# Altium schematic sheet Battery Management System.SchDoc
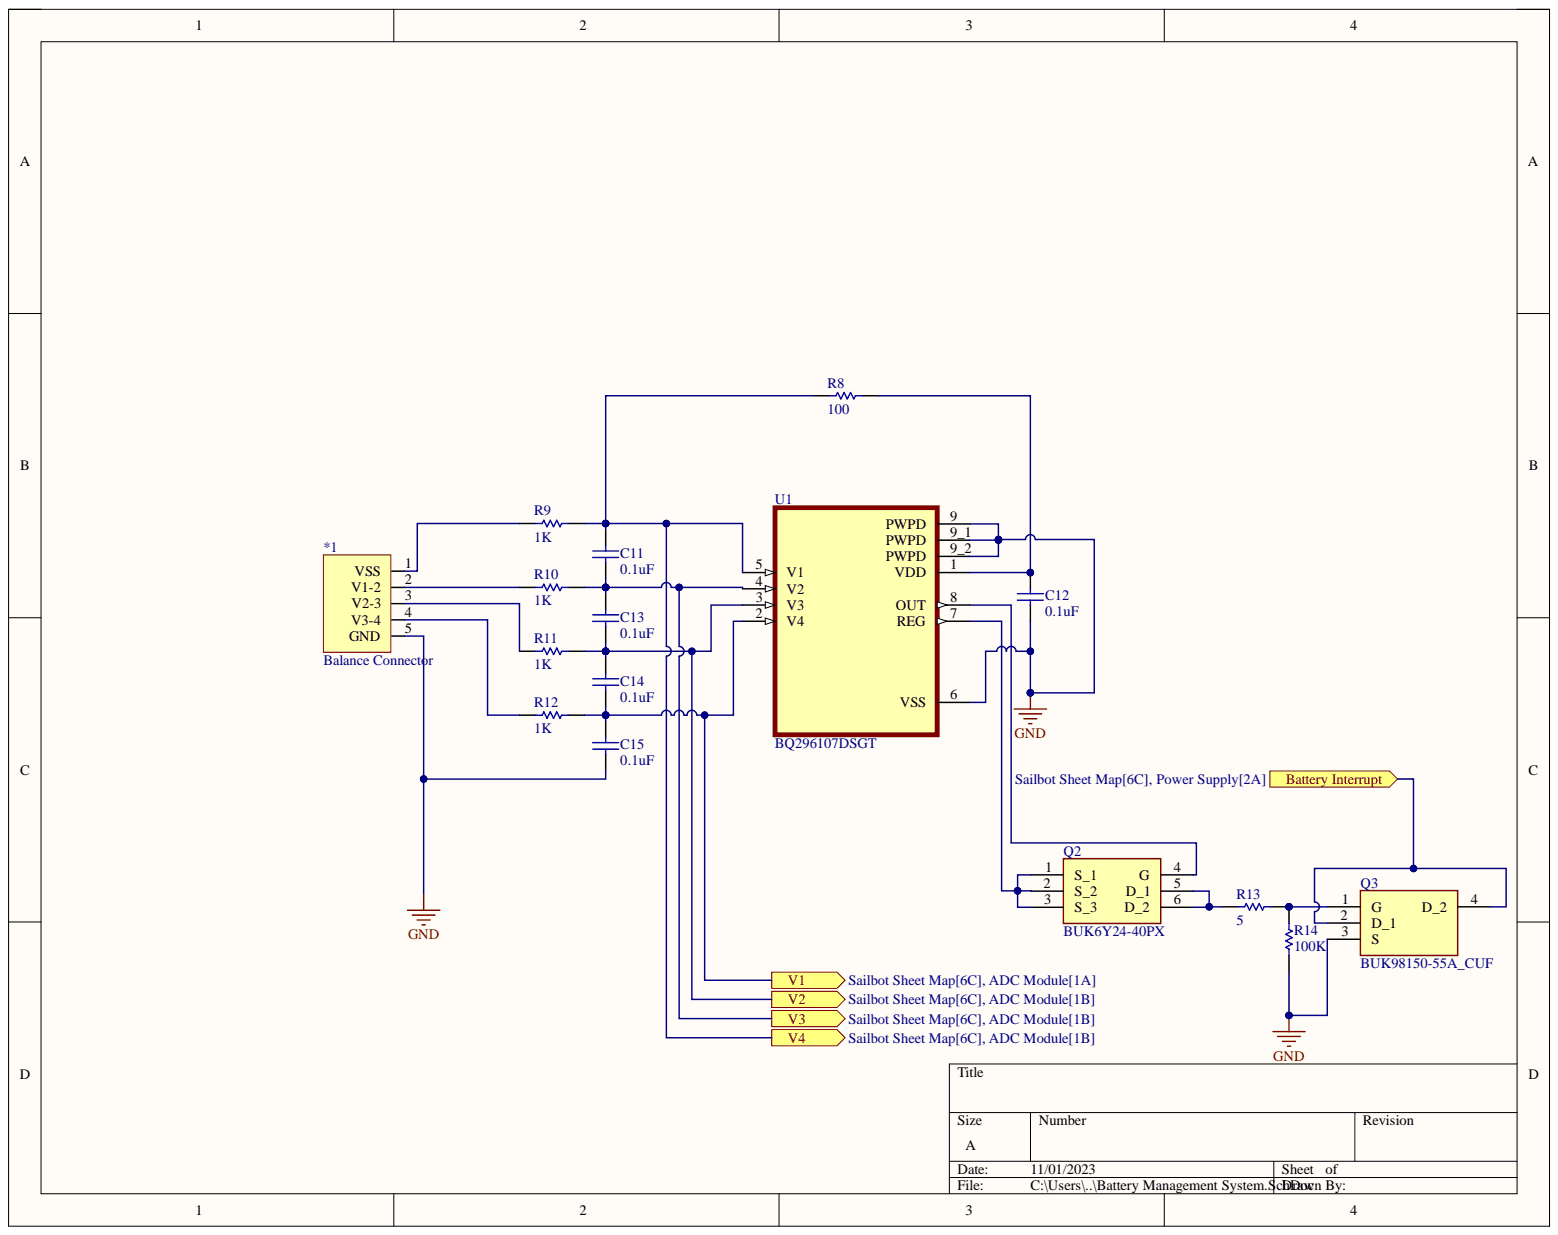
[diagram p0: Battery Management System.SchDoc]

## Parts
*1  Balance Connector
C11  value 0.1uF  (hidden comment: Capacitor)
C12  value 0.1uF  (hidden comment: Capacitor)
C13  value 0.1uF  (hidden comment: Capacitor)
C14  value 0.1uF  (hidden comment: Capacitor)
C15  value 0.1uF  (hidden comment: Capacitor)
Q2  BUK6Y24-40PX  [BUK6Y2440PX]
Q3  BUK98150-55A_CUF  [SOT230P700X180-4N]
R8  value 100  (hidden comment: Resistor)
R9  value 1K  (hidden comment: Resistor)
R10  value 1K  (hidden comment: Resistor)
R11  value 1K  (hidden comment: Resistor)
R12  value 1K  (hidden comment: Resistor)
R13  value 5  (hidden comment: Resistor)
R14  value 100K  (hidden comment: Resistor)
U1  BQ296107DSGT  [SON50P200X200X80-9N]

## Ports
Battery Interrupt, V1, V2, V3, V4

## Nets
GND: *1.5(GND) C12.1 C15.1 Q3.3(S) R14.1 U1.6(VSS) U1.9(PWPD) U1.9_1(PWPD) U1.9_2(PWPD)
Battery Interrupt: Q3.2(D_1) Q3.4(D_2) ; port Battery Interrupt
V1: C14.1 C15.2 R12.2 U1.2(V4) ; port V1
V2: C13.1 C14.2 R11.2 U1.3(V3) ; port V2
V3: C11.1 C13.2 R10.2 U1.4(V2) ; port V3
V4: C11.2 R8.1 R9.2 U1.5(V1) ; port V4
Net*1_1: *1.1(VSS) R9.1
Net*1_2: *1.2(V1-2) R10.1
Net*1_3: *1.3(V2-3) R11.1
Net*1_4: *1.4(V3-4) R12.1
NetC12_2: C12.2 R8.2 U1.1(VDD)
NetQ2_1: Q2.1(S_1) Q2.2(S_2) Q2.3(S_3) U1.7(REG)
NetQ2_4: Q2.4(G) U1.8(OUT)
NetQ2_5: Q2.5(D_1) Q2.6(D_2) R13.1
NetQ3_1: Q3.1(G) R13.2 R14.2

## Library data of the parts
C11-C15 = Capacitor: SimulationGenericComponent: True; Component Kind: Standard; Description: Capacitor; Library Name: Simulation Generic Components.IntLib; Simulation: C_Capacitor_Default
Q2 = BUK6Y24-40PX: NEXPERIA - BUK6Y24-40PX - Power MOSFET, P Channel, 40 V, 39 A, 0.019 ohm, LFPAK56, Surface Mount; Geometry.Height: 1.2mm; Component Kind: Standard; Datasheet Link: https://assets.nexperia.com/documents/data-sheet/BUK6Y24-40P.pdf; Manufacturer_Name: Nexperia; Manufacturer_Part_Number: BUK6Y24-40PX; Mouser Part Number: 771-BUK6Y24-40PX; Mouser Price/Stock: https://www.mouser.co.uk/ProductDetail/Nexperia/BUK6Y24-40PX?qs=vmHwEFxEFR8tyd2WwTEwBg%3D%3D; Library Name: Sailbot Library.SchLib
Q3 = BUK98150-55A_CUF: Trans MOSFET N-CH 55V 5.5A 4-Pin(3+Tab) SC-73 T/R; Manufacturer_Name: Nexperia; Manufacturer_Part_Number: BUK98150-55A/CUF; Geometry.Height: 1.8mm; Component Kind: Standard; Datasheet Link: https://assets.nexperia.com/documents/data-sheet/BUK98150-55A.pdf; Mouser Part Number: 771-BUK98150-55A/CUF; Mouser Price/Stock: https://www.mouser.co.uk/ProductDetail/Nexperia/BUK98150-55A-CUF?qs=Yna0arPQ0CRUeN6OsRm%252BFA%3D%3D; Library Name: Sailbot Library.SchLib
R8-R14 = Resistor: SimulationGenericComponent: True; TC1: 0; Component Kind: Standard; Description: Resistor; Library Name: Simulation Generic Components.IntLib; Simulation: R_Resistor_Default; TC2: 0
U1 = BQ296107DSGT: Battery Protection Li-Ion 2000mA -0.3V to 30V 8-Pin WSON EP T/R; MF: Rochester Electronics, LLC; Availability: Warning; Check_prices: https://www.snapeda.com/parts/BQ296107DSGT/Rochester%20Electronics/view-part/5401981/?ref=eda; Component Kind: Standard; MP: BQ296107DSGT; Package: None; Price: None; Purchase-URL: https://pricing.snapeda.com/search/part/BQ296107DSGT/?ref=eda; SnapEDA_Link: https://www.snapeda.com/parts/BQ296107DSGT/Rochester%20Electronics/view-part/5401981/?ref=snap; Library Name: Sailbot Library.SchLib
*1 = Balance Connector: Component Kind: Standard; Library Name: Sailbot Library.SchLib
